# Altium schematic sheet [2]Battery.SchDoc([2]Battery)
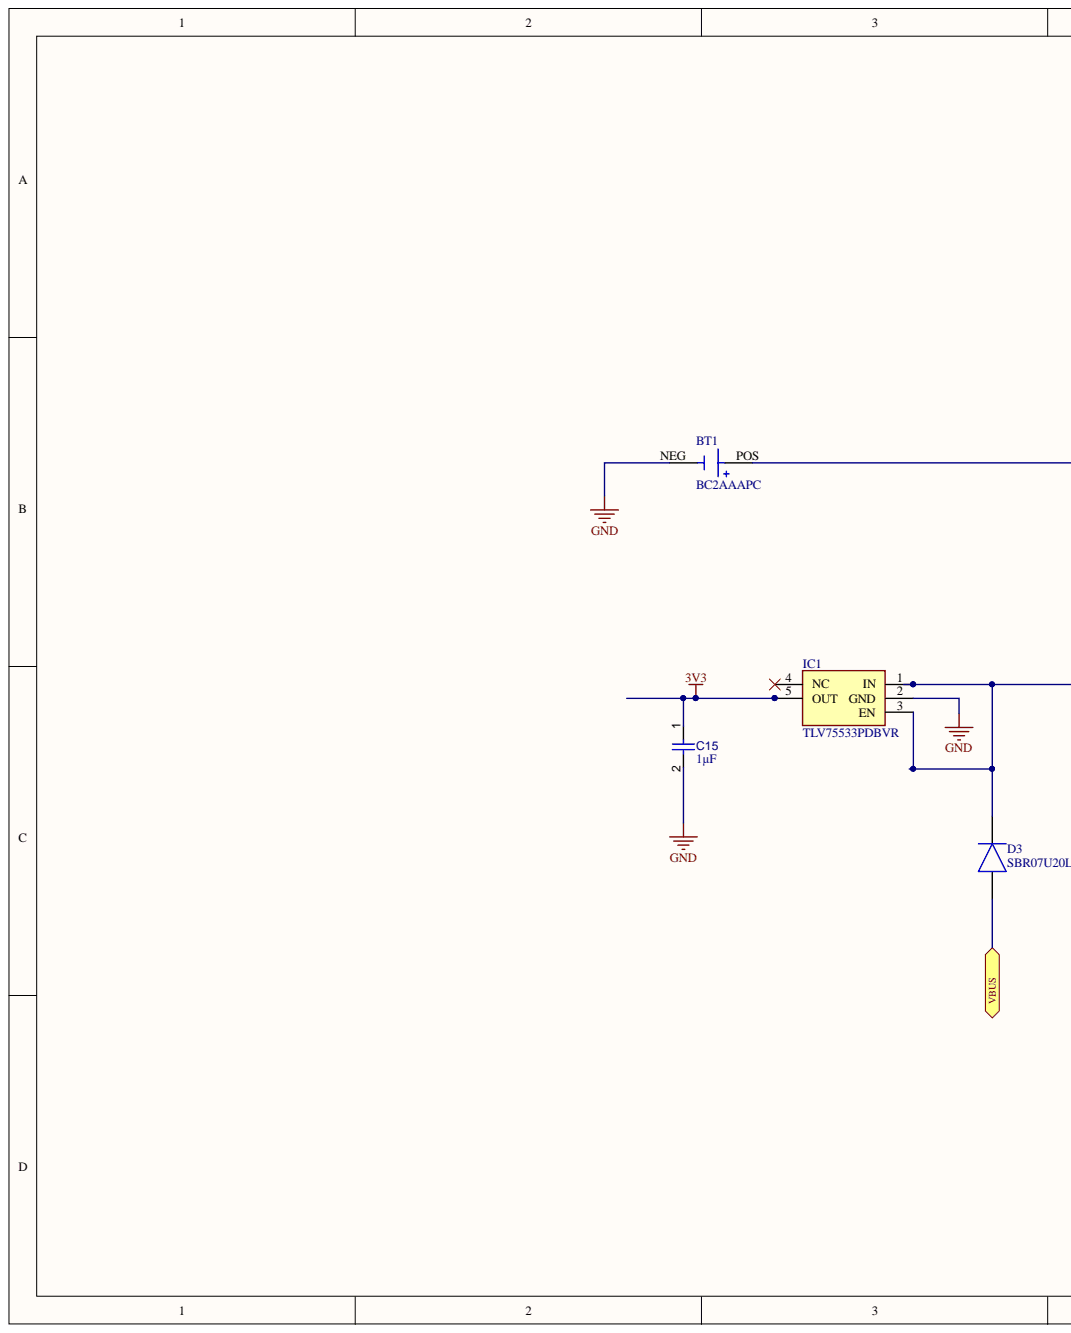
[diagram p0: [2]Battery.SchDoc, left]
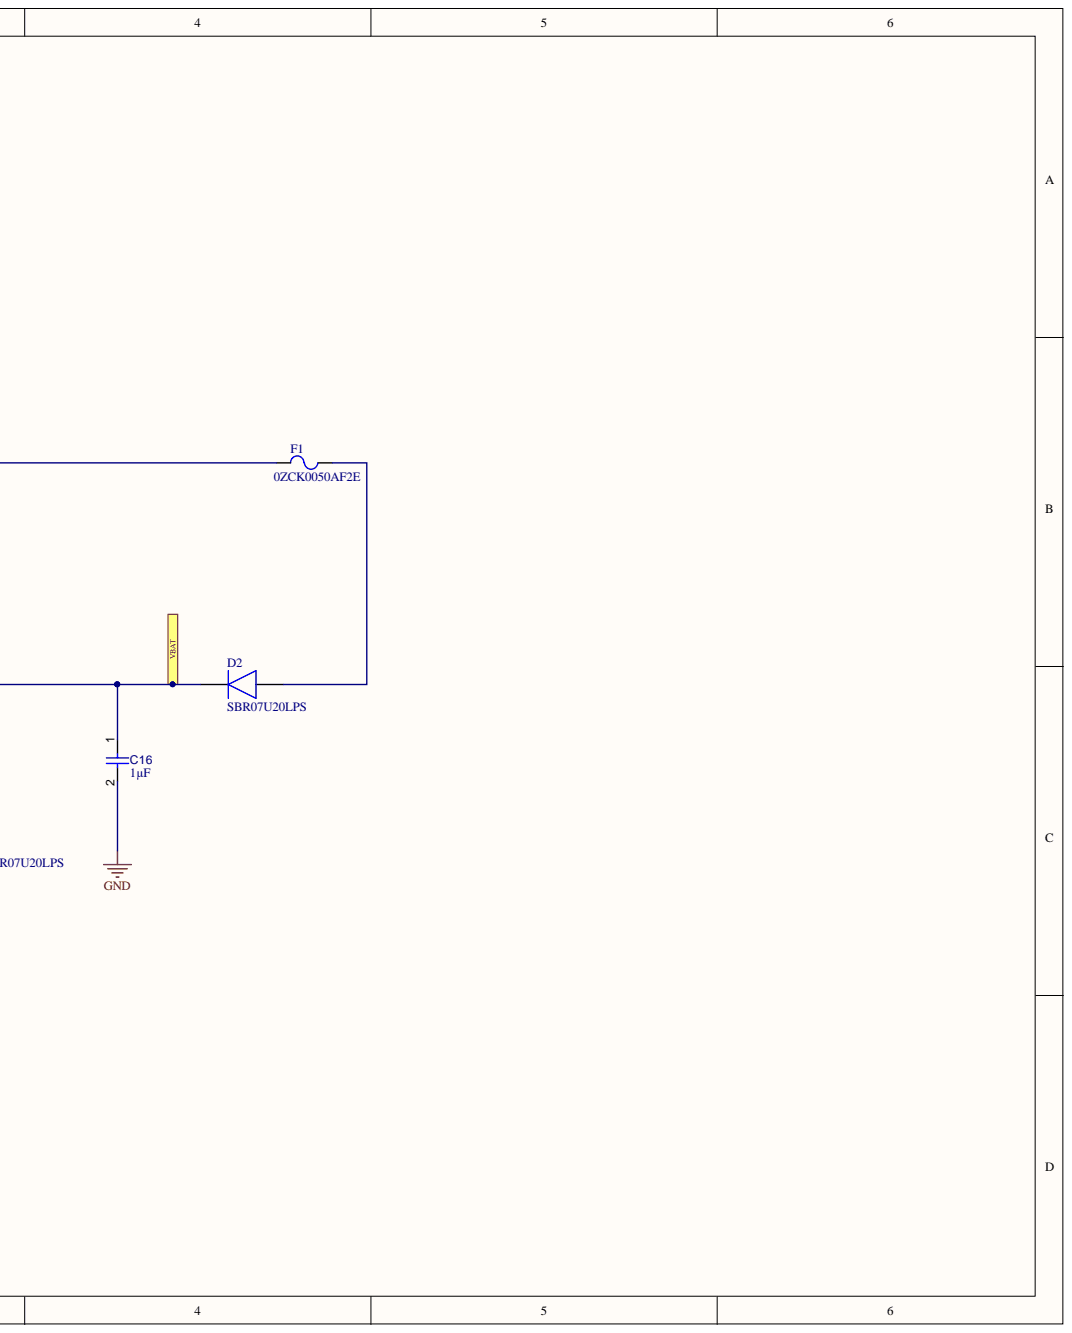
[diagram p1: [2]Battery.SchDoc, right]

## Parts
BT1  BC2AAAPC  [BAT_BC2AAAPC]
C15  value 1µF  [CAPC1608X90X40ML10T20]  (hidden comment: Capacitor 1 uF +/- 10% 25 V 0603)
C16  value 1µF  [CAPC1608X90X40ML10T20]  (hidden comment: Capacitor 1 uF +/- 10% 25 V 0603)
D2  SBR07U20LPS  [CDBQR70HF]
D3  SBR07U20LPS  [CDBQR70HF]
F1  PN: 0ZCK0050AF2E  [PTC RESET FUSE 9V 500MA 0805]  (hidden comment: PTC 9V 500mA)
IC1  TLV75533PDBVR  [SOT95P280X145-5N]

## Ports
VBAT, VBUS

## Nets
3V3: C15.1 IC1.5(OUT)
GND: BT1.NEG C15.2 C16.2 IC1.2(GND)
VBAT: C16.1 D2.1 D3.1 IC1.1(IN) IC1.3(EN) ; port VBAT
VBUS: D3.2 ; port VBUS
NetBT1_POS: BT1.POS F1.1
NetD2_2: D2.2 F1.2

Not connected: IC1 4(NC)

## Library data of the parts
BT1 = BC2AAAPC: BATTERY HOLDER AAA 2 CELL PC PIN; MF: MPD; SnapEDA_Link: https://www.snapeda.com/parts/BC2AAAPC/MPD/view-part/4389080/?ref=snap; Price: None; Package: None; MP: BC2AAAPC; Library Name: BC2AAAPC.IntLib; Component Kind: Standard; Availability: Unavailable
C15 C16 = CMP-001-00005-4: Chip Capacitor, 1 uF, +/- 10%, 25 V, 0603 (1608 Metric); Voltage Rating: 25V; Capacitor Type: Ceramic; Tolerance: 10%; RoHS Compliant: True; Pins: 2; Mounting Technology: SM; Min Operating Temperature: -55°C; Max Operating Temperature: 125°C; Component Kind: Standard; Case/Package: 0603; Library Name: Latium Technologies
D2 D3 = CDSQR4148-HF_1: Diode; Manufacturer_Name: Comchip Technology; Mouser Price/Stock: https://www.mouser.co.uk/ProductDetail/Comchip-Technology/CDSQR4148-HF?qs=2qJf6qQ4IOKNV97fk6Xvow%3D%3D; Mouser Part Number: 750-CDSQR4148-HF; Manufacturer_Part_Number: CDSQR4148-HF; Library Name: Schlib_For_High_Voltage_Sensor.SchLib; Height: 0mm; Datasheet Link: http://www.comchiptech.com/admin/files/product/CDSQR4148-HF-RevC213424.pdf; ComponentLink1URL: https://www.diodes.com/assets/Datasheets/SBR07U20LPS.pdf; ComponentLink1Description: SBR07U20LPS; Component Kind: Standard; Arrow Price/Stock: https://www.arrow.com/en/products/cdsqr4148-hf/comchip-technology; Arrow Part Number: CDSQR4148-HF
F1 = PTC RESET FUSE 9V 500MA 0805: Supplier Part Number 1: 507-2075-1-ND; Supplier 1: Digi-Key; Library Name: MasterLib.SchLib; Description: PTC RESET FUSE 9V 500MA 0805; Datasheet: https://www.belfuse.com/resources/datasheets/circuitprotection/ds-cp-0zck-series.pdf; Component Kind: Standard
IC1 = TLV75533PDBVR: Integrated Circuit; Manufacturer_Name: Texas Instruments; Mouser Price/Stock: https://www.mouser.co.uk/ProductDetail/Texas-Instruments/TLV75533PDBVR?qs=55YtniHzbhAKEwqeO2xJXQ%3D%3D; Mouser Part Number: 595-TLV75533PDBVR; Manufacturer_Part_Number: TLV75533PDBVR; Height: 1.45mm; Datasheet Link: http://www.ti.com/lit/gpn/tlv755p; Component Kind: Standard; Arrow Price/Stock: https://www.arrow.com/en/products/tlv75533pdbvr/texas-instruments; Arrow Part Number: TLV75533PDBVR
